# Altium schematic sheet 1_POWER.SchDoc
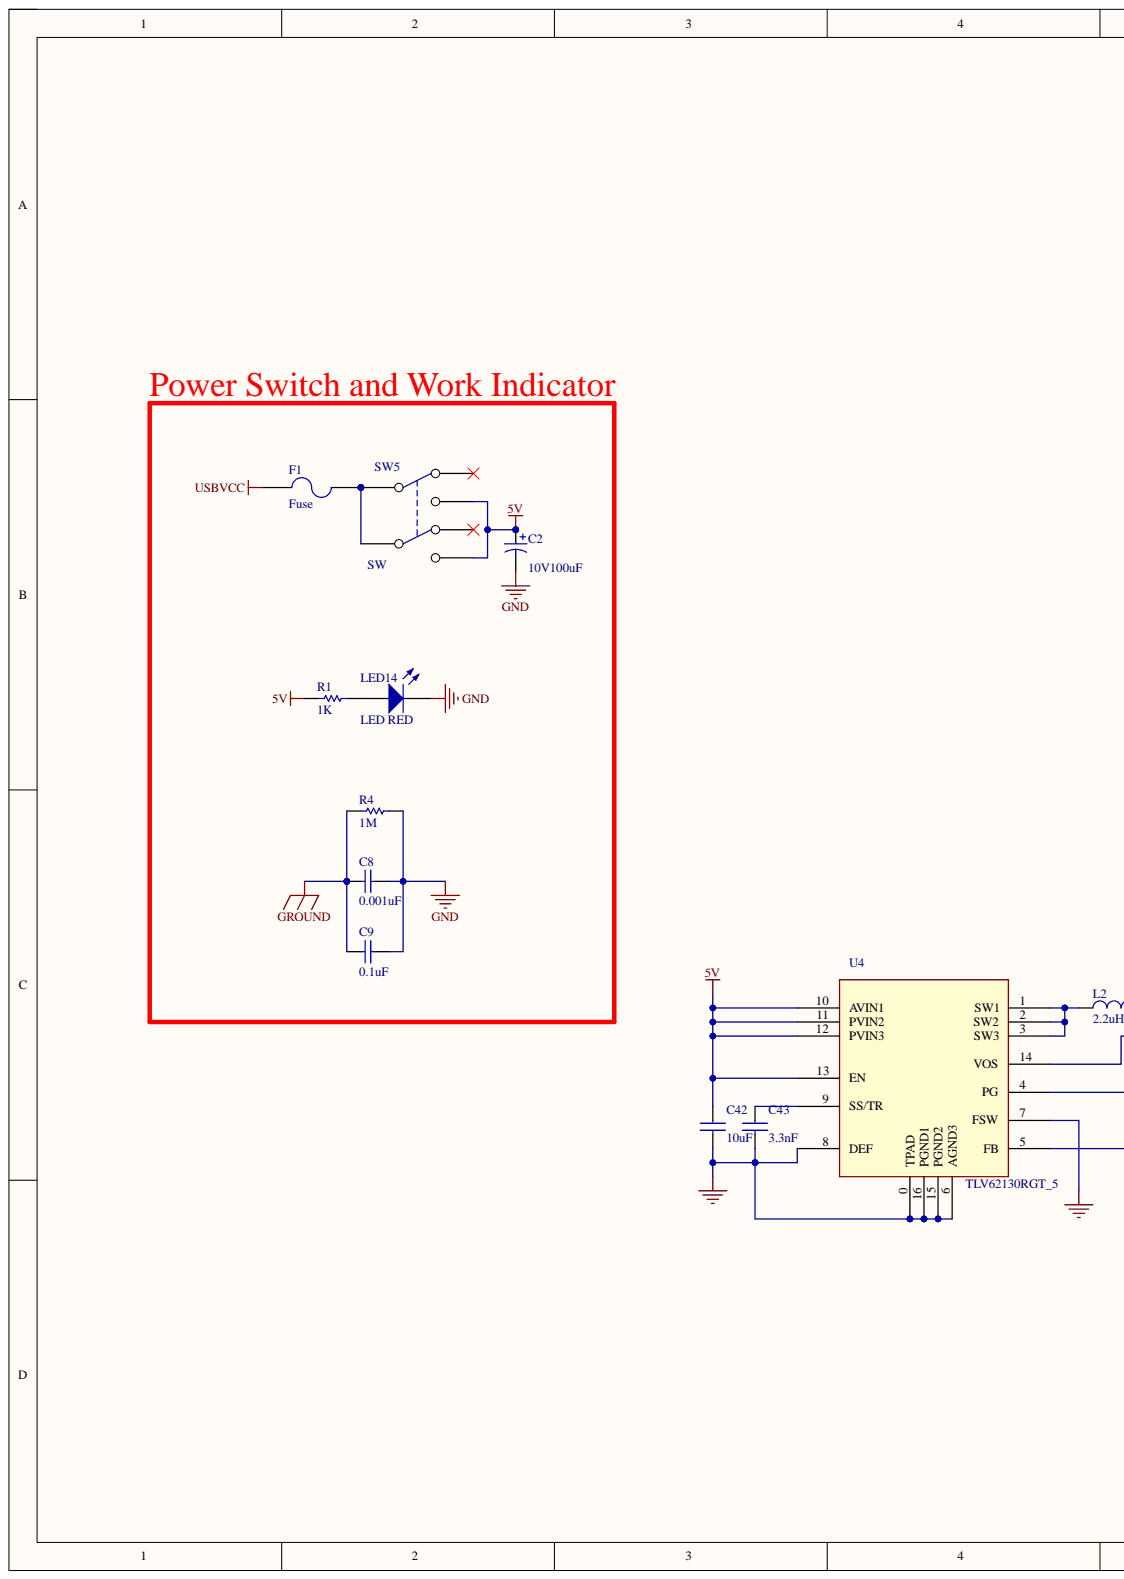
[diagram p0: 1_POWER.SchDoc, left]
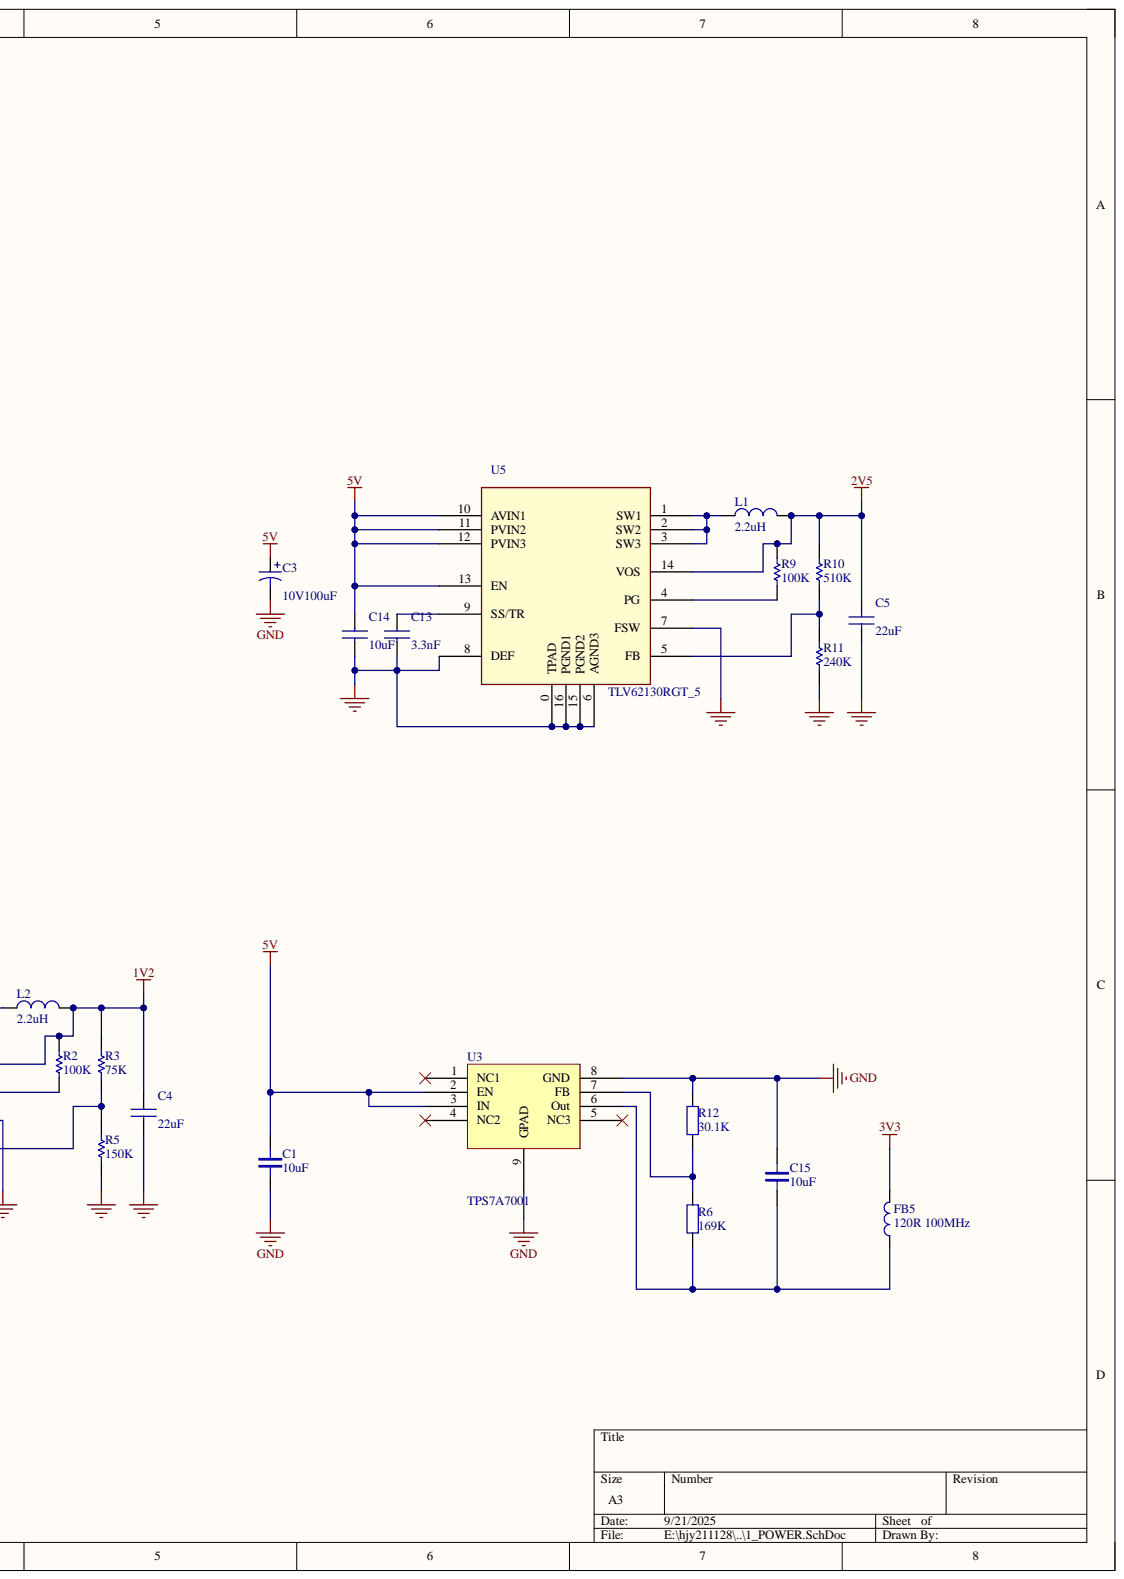
[diagram p1: 1_POWER.SchDoc, right]

## Parts
C1  10uF  [0805C]
C2  value 10V100uF  [CAP5X5]
C3  value 10V100uF  [CAP5X5]
C4  22uF  [C0603]
C5  22uF  [C0603]
C8  0.001uF  [0603]
C9  0.1uF  [0603]
C13  3.3nF  [C0603]
C14  10uF  [C0603]
C15  10uF  [0805C]
C42  10uF  [C0603]
C43  3.3nF  [C0603]
F1  Fuse  [F1808]
FB5  120R 100MHz  [0603]
L1  2.2uH  [FXL0420]
L2  2.2uH  [FXL0420]
LED14  LED RED  [LED0805G]
R1  1K  [0603]
R2  100K  [R0603]
R3  75K  [R0603]
R4  1M  [0603]
R5  150K  [R0603]
R6  169K  [0603R]
R9  100K  [R0603]
R10  510K  [R0603]
R11  240K  [R0603]
R12  30.1K  [0603R]
SW5  SW  [KEY_DPDT]
U3  TPS7A7001  [SOIC-8_L4.9-W3.9-P1.27-LS6.0-BL]
U4  TLV62130RGT_5  [QFN-16_3x3x05p]
U5  TLV62130RGT_5  [QFN-16_3x3x05p]

## Nets
1V2: C4.1 L2.2 R2.2 R3.1 U4.14(VOS)
2V5: C5.1 L1.2 R9.2 R10.1 U5.14(VOS)
3V3: FB5.2
5V: C1.2 C2.1 C3.1 C14.1 C42.1 R1.2 SW5.1 SW5.4 U3.2(EN) U3.3(IN) U4.10(AVIN1) U4.11(PVIN2) U4.12(PVIN3) U4.13(EN) U5.10(AVIN1) U5.11(PVIN2) U5.12(PVIN3) U5.13(EN)
GND: C1.1 C2.2 C3.2 C4.2 C5.2 C8.1 C9.1 C13.2 C14.2 C15.2 C42.2 C43.2 LED14.2 R4.1 R5.2 R11.2 R12.1 U3.8(GND) U3.9(GPAD) U4.0(TPAD) U4.6(AGND3) U4.7(FSW) U4.8(DEF) U4.15(PGND2) U4.16(PGND1) U5.0(TPAD) U5.6(AGND3) U5.7(FSW) U5.8(DEF) U5.15(PGND2) U5.16(PGND1)
GROUND: C8.2 C9.2 R4.2
USBVCC: F1.1
NetC13_1: C13.1 U5.9(SS/TR)
NetC15_1: C15.1 FB5.1 R6.2 U3.6(Out)
NetC43_1: C43.1 U4.9(SS/TR)
NetF1_2: F1.2 SW5.2 SW5.5
NetL1_1: L1.1 U5.1(SW1) U5.2(SW2) U5.3(SW3)
NetL2_1: L2.1 U4.1(SW1) U4.2(SW2) U4.3(SW3)
NetLED14_1: LED14.1 R1.1
NetR2_1: R2.1 U4.4(PG)
NetR3_2: R3.2 R5.1 U4.5(FB)
NetR6_1: R6.1 R12.2 U3.7(FB)
NetR9_1: R9.1 U5.4(PG)
NetR10_2: R10.2 R11.1 U5.5(FB)

Not connected: SW5 3 6; U3 1(NC1) 4(NC2) 5(NC3)

## Library data of the parts
C1 C15 = CAP: Capacitor; Component Kind: Standard; Library Name: General.SchLib
C2 C3 = Cap Pol3: Rated Voltage: A_10V C_16V D_20V E_25V V_35V T_50V; Component Kind: Standard; Library Name: JJHLIB.IntLib
C4 C5 C13 C14 C42 C43 = CAP NP: Component Kind: Standard
C8 C9 = CAP: Capacitor; Value: 104; Component Kind: Standard; LatestRevisionDate: 17-Jul-2002; LatestRevisionNote: Re-released for DXP Platform.; PackageReference: RAD-0.3; Published: 8-Jun-2000; Library Name: cong.IntLib; Publisher: Altium Limited
F1 = Fuse 2: Component Kind: Standard; Description: Fuse; LatestRevisionDate: 17-Jul-2002; LatestRevisionNote: Re-released for DXP Platform.; Library Name: Miscellaneous Devices.IntLib; PackageDescription: Thru-Hole; 2 Leads; PackageReference: PIN-W2/E2.8; Published: 8-Jun-2000; Simulation: FUSE; Publisher: Altium Limited
FB5 = FB: Ferrite Bead; Component Kind: Standard; Library Name: General.SchLib
L1 L2 = L_Inductance: Component Kind: Standard; Library Name: cool.IntLib
LED14 = LED2: Typical RED, GREEN, YELLOW, AMBER GaAs LED; Component Kind: Standard; Library Name: WARSHIP_SCH.lib
R1 R6 R12 = RES: Resistor; Component Kind: Standard
  R1: Library Name: My Footprint.SchLib; Published: 8-Jun-2000; Revision: July-2002: Re-released for DXP Platform.; Value: 1K; Publisher: Altium Limited
  R6 R12: Library Name: General.SchLib
R2 R3 R5 R9-R11 = Res_1: 贴片电阻; Manufacturer: Generic Components; Class: Passive; SubClass: Resistor; Component Kind: Standard; Published: 08/06/2000; Library Name: HGH.SchLib; Publisher: Altium Hobart Technology Centre
R4 = RES: Resistor; Value: 1K; Component Kind: Standard; Library Name: My Footprint.SchLib; Published: 8-Jun-2000; Revision: July-2002: Re-released for DXP Platform.; Publisher: Altium Limited
SW5 = SW KEY-DPDT: Component Kind: Standard
U3 = TPS7A7001: Component Kind: Standard; Library Name: General.SchLib
U4 U5 = TLV62130RGT_5: Component Kind: Standard
